# Altium schematic sheet CANTRICK POWER.SchDoc("U_CANTRICK POWER")
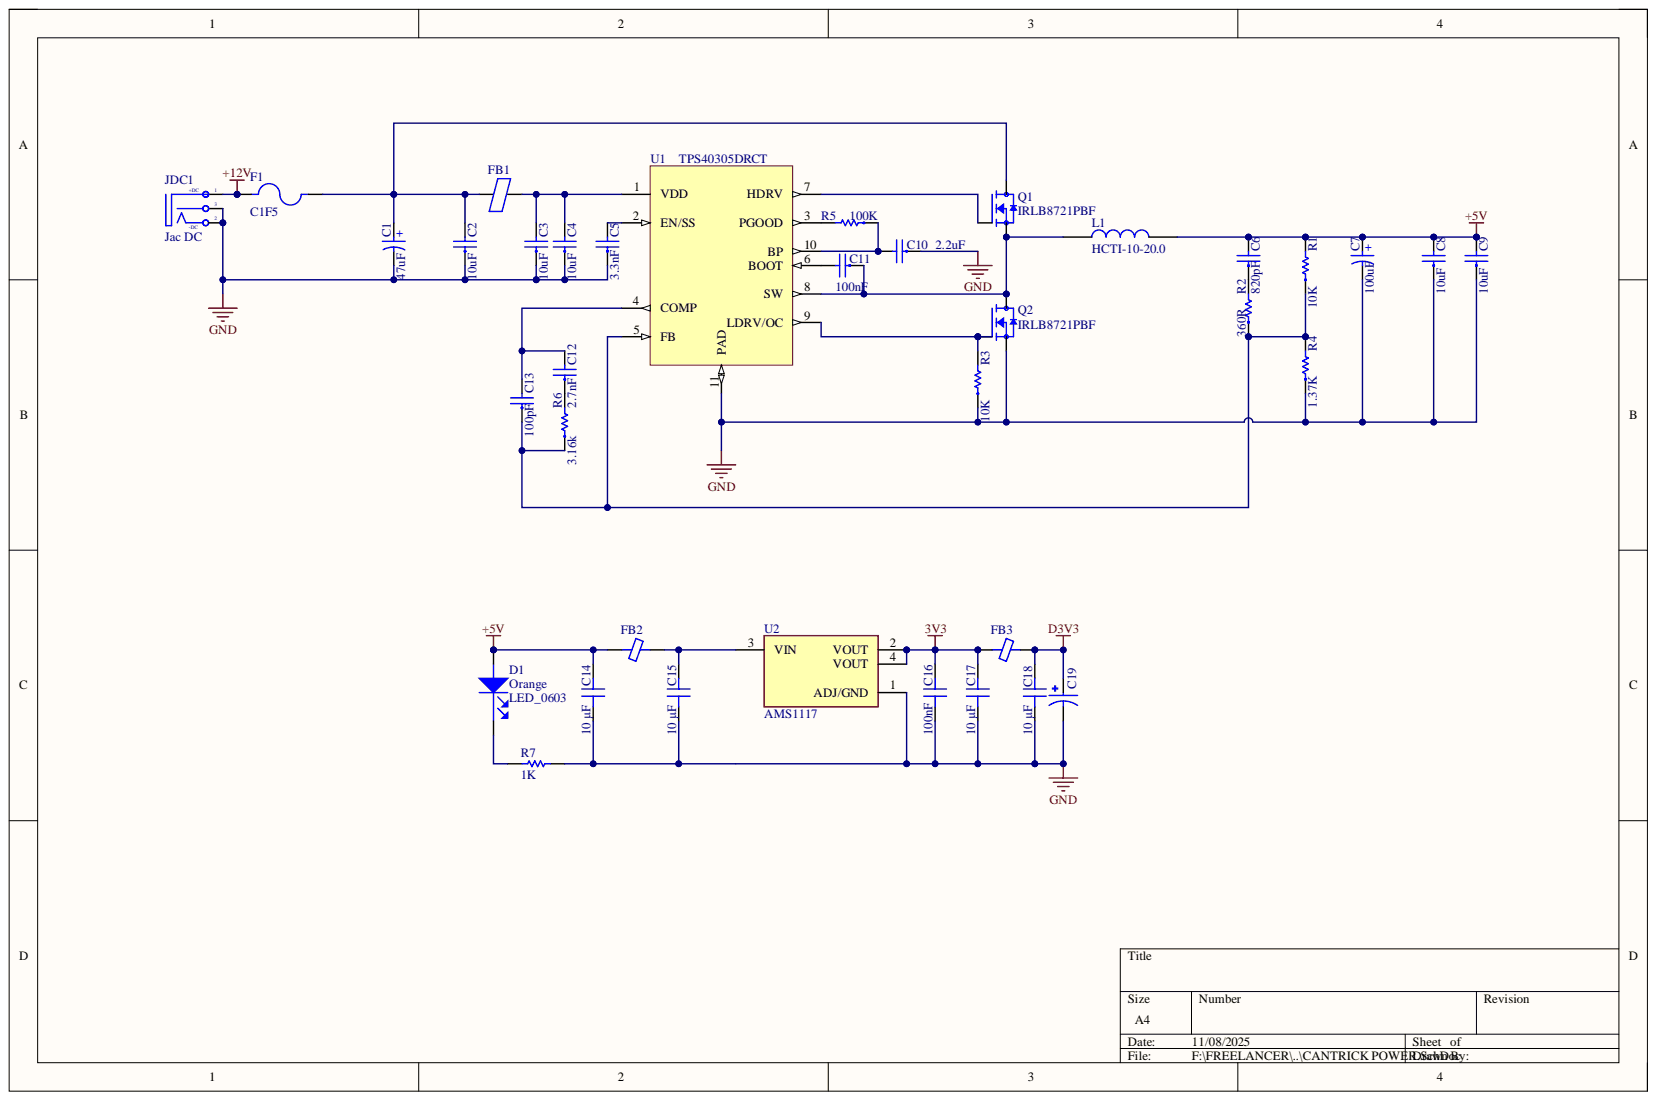
[diagram p0: CANTRICK POWER.SchDoc]

## Parts
C1  47uF  [Cap Aluminum Lytic 100uF 35V 20%]
C2  10uF  [0603]
C3  10uF  [0603]
C4  10uF  [0603]
C5  3.3nF  [0603]
C6  820pF  [0603]
C7  100uF  [Cap Aluminum Lytic 100uF 35V 20%]
C8  10uF  [0603]
C9  10uF  [0603]
C10  2.2uF  [0603]
C11  100nF  [0603]
C12  2.7nF  [0603]
C13  100pF  [0603]
C14  10 µF  [CAP 0603]
C15  10 µF  [CAP 0603]
C16  100nF  [CAP 0603]
C17  10 µF  [CAP 0603]
C18  10 µF  [CAP 0603]
C19  ECA1CHG331  [330UF-5mm]  (value not drawn)
D1  Orange  Package / Case: LED_0603  [LED]
F1  C1F5  [FUSE C1F5]
FB1  100MHz 2A 50mOhm  [FB 0603]  (value not drawn)
FB2  330 Ohms @ 100 MHz  [FB_0603]  (value not drawn)
FB3  330 Ohms @ 100 MHz  [FB_0603]  (value not drawn)
JDC1  Jac DC  [DC0]
L1  HCTI-10-20.0  [IND_HCTI-10-20.0]
Q1  IRLB8721PBF  [TO254P1067X483X2057-3]
Q2  IRLB8721PBF  [TO254P1067X483X2057-3]
R1  10K  [0603]
R2  360R  [0603]
R3  10K  [0603]
R4  1.37K  [0603]
R5  100K  [0603]
R6  3.16k  [0603]
R7  1K  [Res 0603]
U1  TPS40305DRCT  [VREG_V62/16624-01YE]
U2  AMS1117  [AMS1117]

## Nets
3V3: C16.2 C17.2 FB3.1 U2.2(VOUT) U2.4(VOUT)
+5V: C6.2 C7.1 C8.2 C9.2 C14.2 D1.1 FB2.1 L1.2 R1.2
+12V: F1.1 JDC1.1
D3V3: C18.2 C19.1 FB3.2
GND: C1.2 C2.1 C3.1 C4.1 C5.1 C7.2 C8.1 C9.1 C10.1 C14.1 C15.1 C16.1 C17.1 C18.1 C19.2 JDC1.2 JDC1.3 Q2.3 R3.1 R4.1 R7.2 U1.11(PAD) U2.1(ADJ/GND)
NetC1_1: C1.1 C2.2 F1.2 FB1.1 Q1.2
NetC3_2: C3.2 C4.2 FB1.2 U1.1(VDD)
NetC5_2: C5.2 U1.2(EN/SS)
NetC6_1: C6.1 R2.2
NetC10_2: C10.2 R5.1 U1.10(BP)
NetC11_1: C11.1 L1.1 Q1.3 Q2.2 U1.8(SW)
NetC11_2: C11.2 U1.6(BOOT)
NetC12_1: C12.1 R6.2
NetC12_2: C12.2 C13.2 U1.4(COMP)
NetC13_1: C13.1 R1.1 R2.1 R4.2 R6.1 U1.5(FB)
NetC15_2: C15.2 FB2.2 U2.3(VIN)
NetD1_2: D1.2 R7.1
NetQ1_1: Q1.1 U1.7(HDRV)
NetQ2_1: Q2.1 R3.2 U1.9(LDRV/OC)
NetR5_2: R5.2 U1.3(PGOOD)

## Library data of the parts
C1 C7 = Cap Aluminum Lytic 100uF 35V 20%: Cap Aluminum Lytic 100uF 35V 20% (6.3 X 7.7mm) SMD 300mA 2000h 105C T/R; Manufacturer: Wurth Electronics; Manufacturer Part Number: 865080545012; Dissipation Factor: 14%; ESR (Equivalent Series Resistance): 321.392mR; Insulation Resistance: 1MR; Leakage Current: 35uA; Category: Aluminum Electrolytic Capacitors; Component Kind: Standard; ComponentLink1Description: http://www.farnell.com/datasheets/1880164.pdf?src-supplier=Farnell; ComponentLink1URL: http://www.farnell.com/datasheets/1880164.pdf?src-supplier=Farnell; ComponentLink2Description: http://www.farnell.com/datasheets/1880164.pdf?src-supplier=Newark; ComponentLink2URL: http://www.farnell.com/datasheets/1880164.pdf?src-supplier=Newark; ComponentLink3Description: https://katalog.we-online.de/pbs/datasheet/865080545012.pdf?src-supplier=Digikey; ComponentLink3URL: https://katalog.we-online.de/pbs/datasheet/865080545012.pdf?src-supplier=Digikey; ComponentLink4Description: http://www.farnell.com/datasheets/1880164.pdf?src-supplier=Element14; ComponentLink4URL: http://www.farnell.com/datasheets/1880164.pdf?src-supplier=Element14; Length: 7.7mm; Library Name: POWER_PIN_CAMERA.SchLib; Pricing 1: 1=0.31, 50=0.2674, 100=0.2322, 250=0.207, 900=0.1809, 1800=0.1719 (USD); Ripple Current: 300mA; RoHS: Yes; Stock 1: 37792; Supplier 1: Digikey; Supplier Part Number 1: 732-8511-1-ND; Voltage Rating (DC): 35V; Width: 6.6mm
C2-C6 C8-C13 = CAPCER0001 100nF 10% 50V X7R 0603 SMD: CAP CER 100nF 10% 50V X7R 0603 SMD; CERTIFICATION: NA; CLASS: B; LINE: SMT; TMG CODE: None; TYPE: EP; UNIT: PCS; Component Kind: Standard; ComponentLink1Description: Datasheet; ComponentLink1URL: https://datasheet.lcsc.com/lcsc/1811021431_Samsung-Electro-Mechanics-CL10B104KB8NNNC_C1591.pdf; MOUNT: SMD; TMG PN: CAPCER0001; Library Name: NAVIGATION.SCHLIB
C14 C15 C17 C18 = GMC10X7R106K10NT: CAP0603 X7R 10UF 10% 10V; manufacturer: Cal-Chip Electronics, Inc.; Database Table Name: Capacitors - Ceramic - 0603; Applications: General Purpose; Capacitance: 10 µF; Component Kind: Standard; componentlink1description: Datasheet; componentlink1url: https://88ce57.p3cdn1.secureserver.net/wp-content/uploads/2023/01/gmc_series-2.pdf; componentlink2description: DigiKey Link; componentlink2url: https://www.digikey.com/en/products/detail/cal-chip-electronics-inc/GMC10X7R106K10NT/18151126; Device Package: 0603 (1608 Metric); lastupdated: 2/9/2024 8:09:39 PM; Library Name: Celestial Altium Library - altium_library.DbLib; Lifecycle Status: Active; Minimum Order: 1; Mounting Type: Surface Mount, MLCC; Operating Temperature: -55°C ~ 125°C; Package / Case: 0603 (1608 Metric); packaging: TapeAndReel; Part Number: GMC10X7R106K10NT; Part Status: Active; partid: 49835; price: 0.26; series: GMC; Size / Dimension: 0.063" L x 0.031" W (1.60mm x 0.80mm); Supplier 1: DigiKey; Supplier Part Number 1: 4713-GMC10X7R106K10NTCT-ND; Temperature Coefficient: X7R; Thickness (Max): 0.039" (1.00mm); Tolerance: ±10%; Value: 10 µF; Voltage - Rated: 10V
C16 = GMC10X7R106K10NT: CAP0603 X7R 10UF 10% 10V; manufacturer: Cal-Chip Electronics, Inc.; Capacitance: 10 µF; Database Table Name: Capacitors - Ceramic - 0603; Applications: General Purpose; Component Kind: Standard; componentlink1description: Datasheet; componentlink1url: https://88ce57.p3cdn1.secureserver.net/wp-content/uploads/2023/01/gmc_series-2.pdf; componentlink2description: DigiKey Link; componentlink2url: https://www.digikey.com/en/products/detail/cal-chip-electronics-inc/GMC10X7R106K10NT/18151126; Device Package: 0603 (1608 Metric); lastupdated: 2/9/2024 8:09:39 PM; Library Name: Celestial Altium Library - altium_library.DbLib; Lifecycle Status: Active; Minimum Order: 1; Mounting Type: Surface Mount, MLCC; Operating Temperature: -55°C ~ 125°C; Package / Case: 0603 (1608 Metric); packaging: TapeAndReel; Part Number: GMC10X7R106K10NT; Part Status: Active; partid: 49835; price: 0.26; series: GMC; Size / Dimension: 0.063" L x 0.031" W (1.60mm x 0.80mm); Supplier 1: DigiKey; Supplier Part Number 1: 4713-GMC10X7R106K10NTCT-ND; Temperature Coefficient: X7R; Thickness (Max): 0.039" (1.00mm); Tolerance: ±10%; Value: 100nF; Voltage - Rated: 10V
C19 = ECA1CHG331: Cap Aluminum 330uF 16V 20% (8 X 11.5mm) Radial Aluminum Cylindrical Can 3.5mm 260mA 1000 hr 105C Bulk; MF: Panasonic; MP: ECA-1CHG331; Availability: In Stock; Check_prices: https://www.snapeda.com/parts/ECA-1CHG331/Panasonic/view-part/?ref=eda; Component Kind: Standard; Library Name: ECA1CHG331.IntLib; Package: RADIAL-2 Panasonic; Price: None; Purchase-URL: https://pricing.snapeda.com/search/part/ECA-1CHG331/?ref=eda; SnapEDA_Link: https://www.snapeda.com/parts/ECA-1CHG331/Panasonic/view-part/?ref=snap
D1 = LED ORANGE 606NM 0603: LED SMARTLED ORANGE 606NM 0603; Manufacturer: OSRAM Opto Semiconductors Inc; Manufacturer Part Number: LO L29K-H2K1-24-Z; By: Hieu.Nguyen_20191030; Current - Test: 2mA; Lens Style/Size: Rectangle with Flat Top, 1.3mm x 0.8mm; Lens Type: Diffused; Luminous Flux @ Current - Test: 25 mlm; Millicandela Rating: 6.3mcd; Viewing Angle: 160°; Voltage - Forward (Vf) Typ: 1.8V; Wavelength - Peak: 610nm; Category: Optoelectronics; Color: Orange; Component Kind: Standard; ComponentLink1Description: http://catalog.osram-os.com/catalogue/catalogue.do;jsessionid=302CF83AF637AE24E40AEB0ADA267EDD?act=downloadFile&favOid=020000010003f9de000100b6; ComponentLink1URL: http://catalog.osram-os.com/catalogue/catalogue.do;jsessionid=302CF83AF637AE24E40AEB0ADA267EDD?act=downloadFile&favOid=020000010003f9de000100b6; Height: 0.65mm; Library Name: Stm32_Flyback_Driver_250814_1.SCHLIB; Mounting Type: Surface Mount; Packaging: Cut Tape (CT); Pricing 1: 1=0.17, 10=0.141, 100=0.1127, 250=0.0986, 500=0.09016 (USD); RoHS: RoHS Compliant; Size / Dimension: 1.70mm L x 0.80mm W; Stock 1: 15900; Supplier 1: Digi-Key; Supplier Part Number 1: 475-2740-1-ND; Wavelength - Dominant: 606nm
F1 = C1F5: Fuse Chip Fast Acting 5A 125V SMD Solder Pad 1206 3.2 X 1.58 X 0.63mm; Manufacturer: Bel TRP; DC Cold Resistance: 20mR; Fuse Type: Board Mount (Cartridge Style Excluded); Voltage Rating (DC): 63V; Case Code (Imperial): 1206; Case Code (Metric): 3216; Case/Package: 1206; Category: Uncategorized; Component Kind: Standard; ComponentLink1Description: http://www.mouser.com/ds/2/643/C1F-594910.pdf?src-supplier=Mouser; ComponentLink1URL: http://www.mouser.com/ds/2/643/C1F-594910.pdf?src-supplier=Mouser; ComponentLink2Description: http://belfuse.com/pdfs/C1F.pdf?src-supplier=Avnet; ComponentLink2URL: http://belfuse.com/pdfs/C1F.pdf?src-supplier=Avnet; ComponentLink3Description: https://belfuse.com/resources/datasheets/circuitprotection/ds-cp-c1f-series.pdf?src-supplier=Digikey; ComponentLink3URL: https://belfuse.com/resources/datasheets/circuitprotection/ds-cp-c1f-series.pdf?src-supplier=Digikey; Contact Plating: Tin; Library Name: POWER_PIN_CAMERA.SchLib; Manufacturer Part Number: C1F5; Max Operating Temperature: 125°C; Min Operating Temperature: -55°C; Mount: Surface Mount; Number of Pins: 2; Packaging: Cut Tape; RoHS: Yes; Series: C1F; Supplier 1: Newark; Supplier Part Number 1: 48AC8480; Voltage Rating: 125V; Voltage Rating (AC): 125V
FB1 = BLM18PG121SN1D: Ferrite Beads Multi-Layer 120Ohm 25% 100MHz 2A 50mOhm DCR 0603 Paper T/R; Manufacturer: Murata; DC Resistance (DCR): 50mR; Impedance: 120R; Material: Steel,Ferrite; Number of Circuits: 1NUMBER; Number of Lines: 1; Shielding: 202Shielding; Case Code (Imperial): 0603; Case Code (Metric): 1608; Case/Package: 0603; Category: Ferrite Beads and Chips; Component Kind: Standard; ComponentLink1Description: https://datasheet.ciiva.com/5709/1740433-5709171.pdf?src-supplier=Farnell; ComponentLink1URL: https://datasheet.ciiva.com/5709/1740433-5709171.pdf?src-supplier=Farnell; ComponentLink2Description: https://datasheet.ciiva.com/19454/1845013-19454715.pdf?src-supplier=Jameco; ComponentLink2URL: https://datasheet.ciiva.com/19454/1845013-19454715.pdf?src-supplier=Jameco; ComponentLink3Description: https://datasheet.ciiva.com/11799/0900766b81275ca5-11799546.pdf?src-supplier=Rs; ComponentLink3URL: https://datasheet.ciiva.com/11799/0900766b81275ca5-11799546.pdf?src-supplier=Rs; ComponentLink4Description: https://datasheet.ciiva.com/3854/5emiapbde-1-3854039.pdf?src-supplier=Arrow+Electronics; ComponentLink4URL: https://datasheet.ciiva.com/3854/5emiapbde-1-3854039.pdf?src-supplier=Arrow+Electronics; ComponentLink5Description: https://datasheet.ciiva.com/29347/442365-da-01-en-chip-drossel-0603-120r-2000ma-1-6x0-8mm-29347911.pdf?src-supplier=Conrad; ComponentLink5URL: https://datasheet.ciiva.com/29347/442365-da-01-en-chip-drossel-0603-120r-2000ma-1-6x0-8mm-29347911.pdf?src-supplier=Conrad; ComponentLink6Description: https://datasheet.ciiva.com/8021/1740433-8021493.pdf?src-supplier=Element14; ComponentLink6URL: https://datasheet.ciiva.com/8021/1740433-8021493.pdf?src-supplier=Element14; ComponentLink7Description: https://datasheet.ciiva.com/8021/1740433-8021494.pdf?src-supplier=Element14; ComponentLink7URL: https://datasheet.ciiva.com/8021/1740433-8021494.pdf?src-supplier=Element14; ComponentLink8Description: https://datasheet.ciiva.com/14122/blm-series-eng-tds-14122905.pdf?src-supplier=Distrelec; ComponentLink8URL: https://datasheet.ciiva.com/14122/blm-series-eng-tds-14122905.pdf?src-supplier=Distrelec; ComponentLink9Description: https://datasheet.ciiva.com/6212/l0110s0100blm18p-6212502.pdf?src-supplier=Digikey; ComponentLink9URL: https://datasheet.ciiva.com/6212/l0110s0100blm18p-6212502.pdf?src-supplier=Digikey; ComponentLink10Description: https://datasheet.ciiva.com/6212/l0110s0100blm18p-6212504.pdf?src-supplier=Digikey; ComponentLink10URL: https://datasheet.ciiva.com/6212/l0110s0100blm18p-6212504.pdf?src-supplier=Digikey; ComponentLink11Description: https://datasheet.ciiva.com/3081/l0110s0100blm18p-3081632.pdf?src-supplier=Avnet; ComponentLink11URL: https://datasheet.ciiva.com/3081/l0110s0100blm18p-3081632.pdf?src-supplier=Avnet; ComponentLink12Description: https://datasheet.ciiva.com/41449/001172297-da-01-en-drossel-0603-120r-1-6x0-8mm-4000st-41449659.pdf?src-supplier=Conrad; ComponentLink12URL: https://datasheet.ciiva.com/41449/001172297-da-01-en-drossel-0603-120r-1-6x0-8mm-4000st-41449659.pdf?src-supplier=Conrad; Core Material: Ferrite; Current Rating: 2A; DC Current: 2A; DC Resistance-Max: 50mR; Depth: 0.8mm; Frequency: 100MHz; Height: 0.8mm; Lead Free: Lead Free; Length: 1.6mm; Library Name: POWER_PIN_CAMERA.SchLib; Manufacturer Part Number: BLM18PG121SN1D; Max Current Rating: 2A; Max DC Current: 2A; Max Operating Temperature: 125°C; Min Operating Temperature: -55°C; Number of Pins: 2; Package Quantity: 1; Packaging: Tape and Reel; Pricing 1: 1=0.1, 10=0.059, 50=0.047, 100=0.042, 1000=0.029, 10000=0.018 (USD); Radiation Hardening: No; Reach SVHC Compliant: No; RMS Current (Irms): 2A; RoHS: Yes; RoHS Compliant: Yes; Series: BLM18P; Series Resistance: 50mR; Stock 1: 377848; Supplier 1: Mouser; Supplier Part Number 1: 81-BLM18PG121SN1D; Termination: SMD/SMT; Test Frequency: 100MHz; Tolerance: 25%; Width: 0.8mm
FB2 FB3 = BLM18KG331SH1D: FERRITE BEAD 330 OHM 0603 1LN; Manufacturer: Murata Electronics; Current Rating (Max): 1.7A; Database Table Name: Filters - Ferrite Beads and Chips; DC Resistance (DCR) (Max): 80mOhm; Filter Type: Power Line; Number of Lines: 1; Component Kind: Standard; ComponentLink1Description: Datasheet; ComponentLink1URL: https://www.murata.com/en-global/products/productdata/8796737273886/QNFA9101.pdf; ComponentLink2Description: DigiKey Link; ComponentLink2URL: https://www.digikey.com/en/products/detail/murata-electronics/BLM18KG331SH1D/5881246; Device Package: 0603 (1608 Metric); Height (Max): 0.037" (0.95mm); Impedance @ Frequency: 330 Ohms @ 100 MHz; LastUpdated: 3/11/2024 7:43:28 AM; Library Name: Celestial Altium Library - altium_library.DbLib; Lifecycle Status: Active; Minimum Order: 1; Mounting Type: Surface Mount; Operating Temperature: -55°C ~ 125°C; Package / Case: 0603 (1608 Metric); Packaging: TapeAndReel; Part Number: BLM18KG331SH1D; Part Status: Active; PartId: 932; Price: 0.02; Ratings: AEC-Q200; Series: EMIFIL®, BLM18; Size / Dimension: 0.063" L x 0.032" W (1.60mm x 0.80mm); Supplier 1: DigiKey; Supplier Part Number 1: 490-13257-1-ND; Value: 330 Ohms @ 100 MHz
JDC1 = DCIN: Jac DC input; Component Kind: Standard; Value: Jac DC; Library Name: 2502-GMQLITE-MAIN.SCHLIB
L1 = HCTI-10-20.0: High Current Toroidal Inductor | 10 uH; MF: Signal Transformer; Check_prices: https://www.snapeda.com/parts/HCTI-10-20.0/Signal+Transformer/view-part/?ref=eda; Component Kind: Standard; Library Name: HCTI-10-20.0.IntLib; MP: HCTI-10-20.0; Package: Radial Bel Fuse; SnapEDA_Link: https://www.snapeda.com/parts/HCTI-10-20.0/Signal+Transformer/view-part/?ref=snap
Q1 Q2 = IRLB8721PBF: IRLB8721PBF N-channel MOSFET Transistor, 62 A, 30 V, 3-Pin TO-220AB | Infineon IRLB8721PBF; MF: Infineon Technologies; Check_prices: https://www.snapeda.com/parts/IRLB8721PBF/Infineon/view-part/?ref=eda; Component Kind: Standard; MP: IRLB8721PBF; Package: TO-220-3 Infineon; SnapEDA_Link: https://www.snapeda.com/parts/IRLB8721PBF/Infineon/view-part/?ref=snap; Library Name: NAVIGATION.SCHLIB
R1-R6 = RESCHP0427 165K 1% 0603 SMD: RES CHP 165K 1% 1/10W 0603 SMD; CERTIFICATION: NA; CLASS: B; LINE: SMT; TMG CODE: None; TMG PN: RESCHP0167; UNIT: PCS; Component Kind: Standard; ComponentLink1Description: Datasheet; ComponentLink1URL: https://datasheet.lcsc.com/lcsc/2304140030_YAGEO-RT0603FRD07100RL_C160603.pdf; MOUNT: SMD; TYPE: EP; Library Name: 2502-GMQLITE-MAIN.SCHLIB
R7 = Resistor 2.2K: Res Thick Film 0603 2.2K Ohm 5% 1/10W ±200ppm/°C Molded SMD SMD Paper T/R; Manufacturer: Vishay; Manufacturer Part Number: CRCW06032K20JNEA; Max Power Dissipation: 100mW; Resistance: 2.2kR; Voltage Rating: 75V; Case Code (Imperial): 0603; Case Code (Metric): 1608; Case/Package: 0603; Category: Chip SMD Resistors; Component Kind: Standard; ComponentLink1Description: https://datasheet.ciiva.com/2462/dcrcwe3-2462048.pdf?src-supplier=Digikey; ComponentLink1URL: https://datasheet.ciiva.com/2462/dcrcwe3-2462048.pdf?src-supplier=Digikey; ComponentLink2Description: https://datasheet.ciiva.com/16767/70201119-16767464.pdf?src-supplier=Allied+Electronics; ComponentLink2URL: https://datasheet.ciiva.com/16767/70201119-16767464.pdf?src-supplier=Allied+Electronics; ComponentLink3Description: https://datasheet.ciiva.com/2462/dcrcwe3-2462046.pdf?src-supplier=Digikey; ComponentLink3URL: https://datasheet.ciiva.com/2462/dcrcwe3-2462046.pdf?src-supplier=Digikey; ComponentLink4Description: https://datasheet.ciiva.com/5541/dcrcwe3-109170-5541959.pdf?src-supplier=Mouser; ComponentLink4URL: https://datasheet.ciiva.com/5541/dcrcwe3-109170-5541959.pdf?src-supplier=Mouser; ComponentLink5Description: https://datasheet.ciiva.com/16286/dcrcwe3-16286997.pdf?src-supplier=Future+Electronics; ComponentLink5URL: https://datasheet.ciiva.com/16286/dcrcwe3-16286997.pdf?src-supplier=Future+Electronics; Construction: Rectangular; Contact Plating: Tin; Depth: 0.85mm; Features: Automotive AEC-Q200; Height: 0.45mm; Lead Free: 5697LeadFree; Length: 1.55mm; Library Name: Stm32_Flyback_Driver_250814_1.SCHLIB; Max Operating Temperature: 155°C; Military Standard: Not; Min Operating Temperature: -55°C; Number of Terminations: 2; Package Quantity: 5000; Packaging: Tape and Reel; Power Rating: 100mW; Pricing 1: 5000=0.0053 (USD); Radiation Hardening: No; Ratings: AEC-Q200; Reach SVHC Compliant: Unknown; Resistance Tolerance: 5%; Resistor Type: Thick Film; RoHS: Yes; RoHS Compliant: Yes; Series: CRCW; Size Code: 0603; Stock 1: 130000; Supplier 1: Arrow Electronics; Supplier Part Number 1: CRCW06032K20JNEA; Temperature Coefficient: 200ppm/°C; Termination: SMD/SMT; Tolerance: 5%; Weight: 7.1E-05oz; Width: 0.85mm
U1 = TPS40305DRCT: 3-V to 20-V, 25-A, 1.2-MHz synchronous buck controller with FSS; MF: Texas Instruments; Check_prices: https://www.snapeda.com/parts/TPS40305DRCT/Texas+Instruments/view-part/?ref=eda; Component Kind: Standard; Library Name: TPS40305DRCT.SchLib; MP: TPS40305DRCT; Package: VSON-10 Texas Instruments; SnapEDA_Link: https://www.snapeda.com/parts/TPS40305DRCT/Texas+Instruments/view-part/?ref=snap
U2 = AMS1117: 1A LOW DROPOUT VOLTAGE REGULATOR SOT-223; MF: Advanced Monolithic Systems; Availability: Not in stock; Check_prices: https://www.snapeda.com/parts/AMS1117/Advanced+Monolithic+Systems/view-part/?ref=eda; Component Kind: Standard; Library Name: AMS1117.SchLib; MP: AMS1117; Package: SOT-223 Seeed Technology; Price: None; SnapEDA_Link: https://www.snapeda.com/parts/AMS1117/Advanced+Monolithic+Systems/view-part/?ref=snap
